# Altium schematic sheet IO.SchDoc
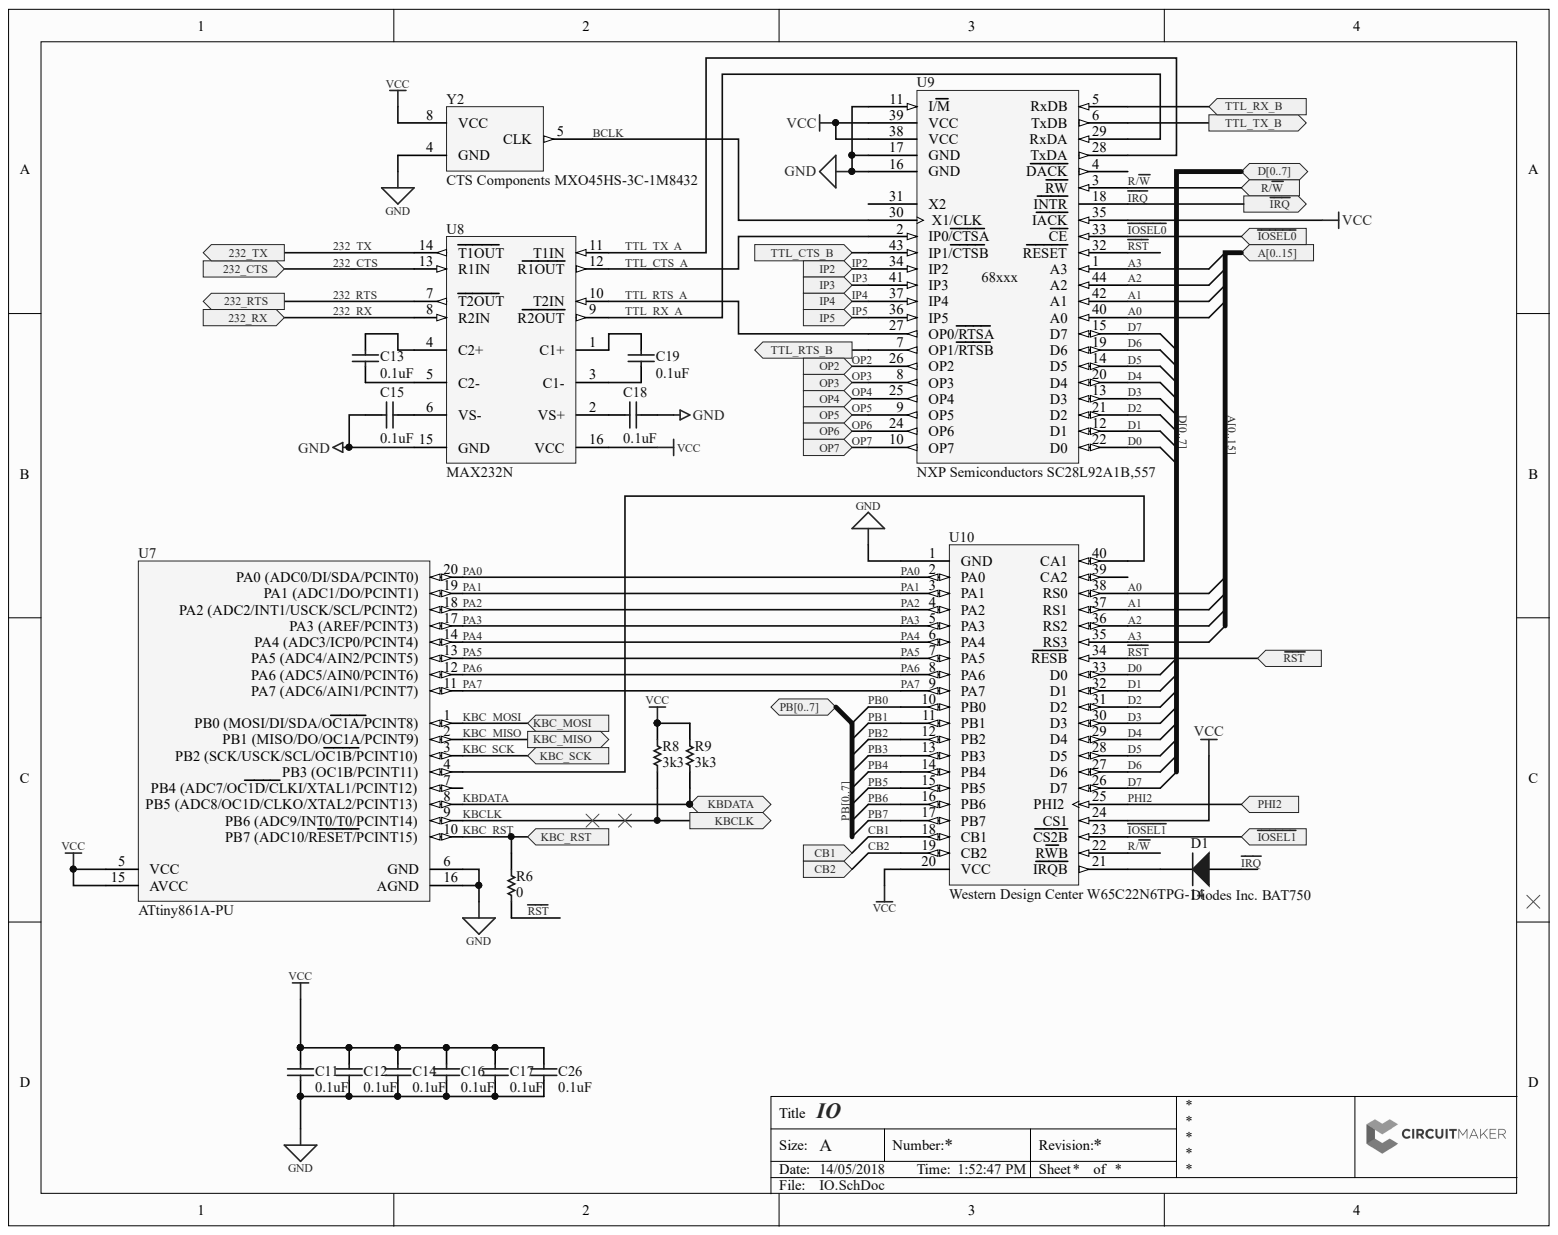
[diagram p0: IO.SchDoc]

## Parts
C11  0.1uF  [CAPC1608X90X35NL15T15]
C12  0.1uF  [CAPC1608X90X35NL15T15]
C13  0.1uF  [CAPC1608X90X35NL15T15]
C14  0.1uF  [CAPC1608X90X35NL15T15]
C15  0.1uF  [CAPC1608X90X35NL15T15]
C16  0.1uF  [CAPC1608X90X35NL15T15]
C17  0.1uF  [CAPC1608X90X35NL15T15]
C18  0.1uF  [CAPC1608X90X35NL15T15]
C19  0.1uF  [CAPC1608X90X35NL15T15]
C26  0.1uF  [CAPC1608X90X35NL15T15]
D1  Diodes Inc. BAT750  Manufacturer Part Number: BAT750  [PCB-424japtr4l4h57iluqnj-1]
R6  0  [RESC1608X55X30ML20T10]
R8  3k3  [RESC1608X55X30ML20T10]
R9  3k3  [RESC1608X55X30ML20T10]
U7  ATtiny861A-PU  [20P3]
U8  MAX232N  [TI-N16]
U9  NXP Semiconductors SC28L92A1B,557  Manufacturer Part Number: SC28L92A1B,557  [PCB-gqi0ukwbi8o3vwizgqut-1]
U10  Western Design Center W65C22N6TPG-14  Manufacturer Part Number: W65C22N6TPG-14  [PCB-fzv7zhlkwo7pvhyncebf-1]
Y2  CTS Components MXO45HS-3C-1M8432  Manufacturer Part Number: MXO45HS-3C-1M8432  [PCB-9wf0uyermkn85h0e2qa4-1]

## Ports
232_CTS, 232_RTS, 232_RX, 232_TX, A0, A1, A2, A3, A4, A5, A6, A7, A8, A9, A10, A11, A12, A13, A14, A15, A[0..15], CB1, CB2, D0, D1, D2, D3, D4, D5, D6, D7, D[0..7], IP2, IP3, IP4, IP5, KBC_MISO, KBC_MOSI, KBC_RST, KBC_SCK, KBCLK, KBDATA, OP2, OP3, OP4, OP5, OP6, OP7, PB0, PB1, PB2, PB3, PB4, PB5, PB6, PB7, PB[0..7], PHI2, R/~{W}, TTL_CTS_B, TTL_RTS_B, TTL_RX_B, TTL_TX_B, ~{IOSEL0}, ~{IOSEL1}, ~{IRQ}, ~{RST}

## Nets
GND: C11.1 C12.1 C14.1 C15.1 C16.1 C17.1 C18.2 C26.1 U7.6(GND) U7.16(AGND) U8.15(GND) U9.11(I/M) U9.16(GND) U9.17(GND) U10.1(GND) Y2.4
VCC: C11.2 C12.2 C14.2 C16.2 C17.2 C26.2 R8.2 R9.2 U7.5(VCC) U7.15(AVCC) U8.16(VCC) U9.35(IACK) U9.38(VCC) U9.39(VCC) U10.20(VCC) U10.24(CS1) Y2.8
232_CTS: U8.13(R1IN) ; port 232_CTS
232_RTS: U8.7(T2OUT) ; port 232_RTS
232_RX: U8.8(R2IN) ; port 232_RX
232_TX: U8.14(T1OUT) ; port 232_TX
A0: U9.40(A0) U10.38(RS0) ; port A[0..15]  [also labelled A]
A1: U9.42(A1) U10.37(RS1) ; port A[0..15]  [also labelled A]
A2: U9.44(A2) U10.36(RS2) ; port A[0..15]  [also labelled A]
A3: U9.1(A3) U10.35(RS3) ; port A[0..15]  [also labelled A]
BCLK: U9.30(X1/CLK) Y2.5
CB1: U10.18(CB1) ; port CB1
CB2: U10.19(CB2) ; port CB2
D0: U9.22(D0) U10.33(D0) ; port D[0..7]  [also labelled D]
D1: U9.12(D1) U10.32(D1) ; port D[0..7]  [also labelled D]
D2: U9.21(D2) U10.31(D2) ; port D[0..7]  [also labelled D]
D3: U9.13(D3) U10.30(D3) ; port D[0..7]  [also labelled D]
D4: U9.20(D4) U10.29(D4) ; port D[0..7]  [also labelled D]
D5: U9.14(D5) U10.28(D5) ; port D[0..7]  [also labelled D]
D6: U9.19(D6) U10.27(D6) ; port D[0..7]  [also labelled D]
D7: U9.15(D7) U10.26(D7) ; port D[0..7]  [also labelled D]
~{IOSEL0}: U9.33(CE) ; port ~{IOSEL0}
~{IOSEL1}: U10.23(CS2B) ; port ~{IOSEL1}
~{IRQ}: D1.1 U9.18(INTR) ; port ~{IRQ}
IP2: U9.34(IP2) ; port IP2
IP3: U9.41(IP3) ; port IP3
IP4: U9.37(IP4) ; port IP4
IP5: U9.36(IP5) ; port IP5
KBC_MISO: U7.2(PB1_(MISO/DO/OC1A/PCINT9)) ; port KBC_MISO
KBC_MOSI: U7.1(PB0_(MOSI/DI/SDA/OC1A/PCINT8)) ; port KBC_MOSI
KBC_RST: R6.1 U7.10(PB7_(ADC10/RESET/PCINT15)) ; port KBC_RST
KBC_SCK: U7.3(PB2_(SCK/USCK/SCL/OC1B/PCINT10)) ; port KBC_SCK
KBCLK: R8.1 U7.9(PB6_(ADC9/INT0/T0/PCINT14)) ; port KBCLK
KBDATA: R9.1 U7.8(PB5_(ADC8/OC1D/CLKO/XTAL2/PCINT13)) ; port KBDATA
OP2: U9.26(OP2) ; port OP2
OP3: U9.8(OP3) ; port OP3
OP4: U9.25(OP4) ; port OP4
OP5: U9.9(OP5) ; port OP5
OP6: U9.24(OP6) ; port OP6
OP7: U9.10(OP7) ; port OP7
PA0: U7.20(PA0_(ADC0/DI/SDA/PCINT0)) U10.2(PA0)
PA1: U7.19(PA1_(ADC1/DO/PCINT1)) U10.3(PA1)
PA2: U7.18(PA2_(ADC2/INT1/USCK/SCL/PCINT2)) U10.4(PA2)
PA3: U7.17(PA3_(AREF/PCINT3)) U10.5(PA3)
PA4: U7.14(PA4_(ADC3/ICP0/PCINT4)) U10.6(PA4)
PA5: U7.13(PA5_(ADC4/AIN2/PCINT5)) U10.7(PA5)
PA6: U7.12(PA6_(ADC5/AIN0/PCINT6)) U10.8(PA6)
PA7: U7.11(PA7_(ADC6/AIN1/PCINT7)) U10.9(PA7)
PB0: U10.10(PB0) ; port PB[0..7]  [also labelled PB]
PB1: U10.11(PB1) ; port PB[0..7]  [also labelled PB]
PB2: U10.12(PB2) ; port PB[0..7]  [also labelled PB]
PB3: U10.13(PB3) ; port PB[0..7]  [also labelled PB]
PB4: U10.14(PB4) ; port PB[0..7]  [also labelled PB]
PB5: U10.15(PB5) ; port PB[0..7]  [also labelled PB]
PB6: U10.16(PB6) ; port PB[0..7]  [also labelled PB]
PB7: U10.17(PB7) ; port PB[0..7]  [also labelled PB]
PHI2: U10.25(PHI2) ; port PHI2
R/~{W}: U9.3(RW) U10.22(RWB) ; port R/~{W}
~{RST}: R6.2 U9.32(RESET) U10.34(RESB) ; port ~{RST}
TTL_CTS_A: U8.12(R1OUT) U9.2(IP0/CTSA)
TTL_CTS_B: U9.43(IP1/CTSB) ; port TTL_CTS_B
TTL_RTS_A: U8.10(T2IN) U9.27(OP0/RTSA)
TTL_RTS_B: U9.7(OP1/RTSB) ; port TTL_RTS_B
TTL_RX_A: U8.9(R2OUT) U9.29(RxDA)
TTL_RX_B: U9.5(RxDB) ; port TTL_RX_B
TTL_TX_A: U8.11(T1IN) U9.28(TxDA)
TTL_TX_B: U9.6(TxDB) ; port TTL_TX_B
NetC13_1: C13.1 U8.5(C2-)
NetC13_2: C13.2 U8.4(C2+)
NetC15_2: C15.2 U8.6(VS-)
NetC18_1: C18.1 U8.2(VS+)
NetC19_1: C19.1 U8.3(C1-)
NetC19_2: C19.2 U8.1(C1+)
NetD1_3: D1.3 U10.21(IRQB)
NetU7_4: U7.4(PB3_(OC1B/PCINT11)) U10.40(CA1)
A4: ; port A[0..15]  [also labelled A]
A5: ; port A[0..15]  [also labelled A]
A6: ; port A[0..15]  [also labelled A]
A7: ; port A[0..15]  [also labelled A]
A8: ; port A[0..15]  [also labelled A]
A9: ; port A[0..15]  [also labelled A]
A10: ; port A[0..15]  [also labelled A]
A11: ; port A[0..15]  [also labelled A]
A12: ; port A[0..15]  [also labelled A]
A13: ; port A[0..15]  [also labelled A]
A14: ; port A[0..15]  [also labelled A]
A15: ; port A[0..15]  [also labelled A]

Not connected: U7 7(PB4_(ADC7/OC1D/CLKI/XTAL1/PCINT12)); U9 4(DACK) 31(X2); U10 39(CA2)

## Library data of the parts
C11-C19 C26 = CMP-15328962-11: 0603 0.1uF Capacitor; Capacitance: 1e-07 F; Capacitance Tolerance: ±10%; Dielectric Characteristic: X7R; Dielectric Material: Ceramic Multilayer; Insulation Resistance: 1e+11 Ω; Lead-Free Status: Lead Free; Voltage Rating (DC): 16.0 V; Case/Package: 0603; Component Kind: Standard; Library Name: CommunityVault; Mounting Style: Surface Mount; Number of Pins: 2; OctopartID: 0cd5c6920958d312; Octopart Url: https://octopart.com/0603yc104kat2a-avx+interconnect+%2F+elco-55386347; REACH SVHC Compliance: No SVHC; RoHS: Compliant; Simulation: SIM-9frhrb8yuhxfmab8hy02-1; Size-Height: 0.00086 m; Size-Length: 0.0016002 m; Size-Width: 0.0008128 m
D1 = Diodes Inc. BAT750-1: VR = 40V  IF = 750mA  VF < 490mV @ 750mA SOT23; Case/Package: SOT-23; Component Kind: Standard; Library Name: FavoritesLibrary; Lifecycle Status: Active; OctopartID: bfb87653b293607f; Octopart URL: http://octopart.com/bat750-diodes+inc.-14755917; RoHS: Compliant
R6 R8 R9 = CMP-3658446-2: Thick Film Resistors - SMD 1/10watt 3.3Kohms 1%; Lead-Free Status: Lead Free; Power Rating: 0.1 W; Resistance: 3300.0 Ω; Case/Package: 0603; Component Kind: Standard; Library Name: CommunityVault; Mounting Style: Surface Mount; OctopartID: 55949cd3100e8a53; Octopart Url: https://octopart.com/rk73h1jttd3301f-koa+speer-5071100; Resistance Tolerance: ±1%; RoHS: Compliant
U7 = CMP-2386869-3: 8-bit AVR Microcontroller, 2.7-5.5V, 20MHz, 8KB Flash, 512 Bytes EEPROM, 512 Bytes SRAM, 20-pin PDIP, Industrial Grade (-40°C to 85°C); Access Time: 2e-05 s; Clock Speed: 20000000.0 Hz; FLASH Memory Size: 8192 B; Lead-Free Status: Lead Free; Number of Bits: 8; Number of I/O Pins: 16; RAM Memory Size: 512 B; Supply Voltage (DC): 5.0 V; Case/Package: DIP; Component Kind: Standard; Core Architecture: AVR; Library Name: CommunityVault; Mounting Style: Through Hole; Number of Pins: 20; OctopartID: 25b2eee496d5fa75; Octopart Url: https://octopart.com/attiny861-20pu-atmel-39622145; Packaging: Tube; REACH SVHC Compliance: No SVHC; RoHS: Compliant
U8 = CMP-14952750-3: Dual EIA-232 Driver / Receiver, 0 to 70 degC, 16-Pin PDIP (N), Pb-Free (RoHS); Data Rate: 120000.0 bps; Drivers Per Package: 2; Lead-Free Status: Lead Free; Supply Current: 0.008 A; Component Kind: Standard; Library Name: CommunityVault; Lifecycle Status: Active; Mounting Style: Through Hole; Number of Pins: 16; OctopartID: a8826188882e7745; Octopart Url: https://octopart.com/max232n-texas+instruments-1482; Packaging: Tube; REACH SVHC Compliance: No SVHC; RoHS: Compliant
U9 = CMP-1b55d2ce0277c492-1: Integrated circuits from leading manufacturers. Please read our extensive documentation which is free to download.; Data Rate: 230 kbps; Lead-Free Status: Lead Free; Supply Voltage (DC): 2.97 V (min); Case/Package: QFP; Component Kind: Standard; Library Name: CommunityVault; Lifecycle Status: Active; Mounting Style: Surface Mount; Number of Pins: 44; OctopartID: 1b55d2ce0277c492; Octopart URL: http://octopart.com/sc28l92a1b%2C557-nxp+semiconductors-9518148; Packaging: Tray; REACH SVHC Compliance: No SVHC; RoHS: Compliant
U10 = CMP-efc5278ec54e3002-3: W65C22N Versatile Interface Adapter (VIA); Case/Package: PDIP; Component Kind: Standard; Library Name: CommunityVault; Mounting Style: Through Hole; OctopartID: efc5278ec54e3002; Octopart URL: http://octopart.com/w65c22n6tpg-14-western+design+center-21041133; Packaging: Tube; RoHS: Compliant
Y2 = CMP-6487ad4d37bebb40-3: Oscillator XO 1.8432MHz ±50ppm 50pF (Stability) HCMOS/TTL 55% 5V 4-Pin Metal DIP Thru-Hole Tray; Component Kind: Standard; Library Name: CommunityVault; Mounting Style: Through Hole; OctopartID: 6487ad4d37bebb40; Octopart URL: http://octopart.com/mxo45hs-3c-1m8432-cts+components-12613215; RoHS: Compliant
